# Altium schematic sheet NFC Controller.SchDoc("NFC Controller")
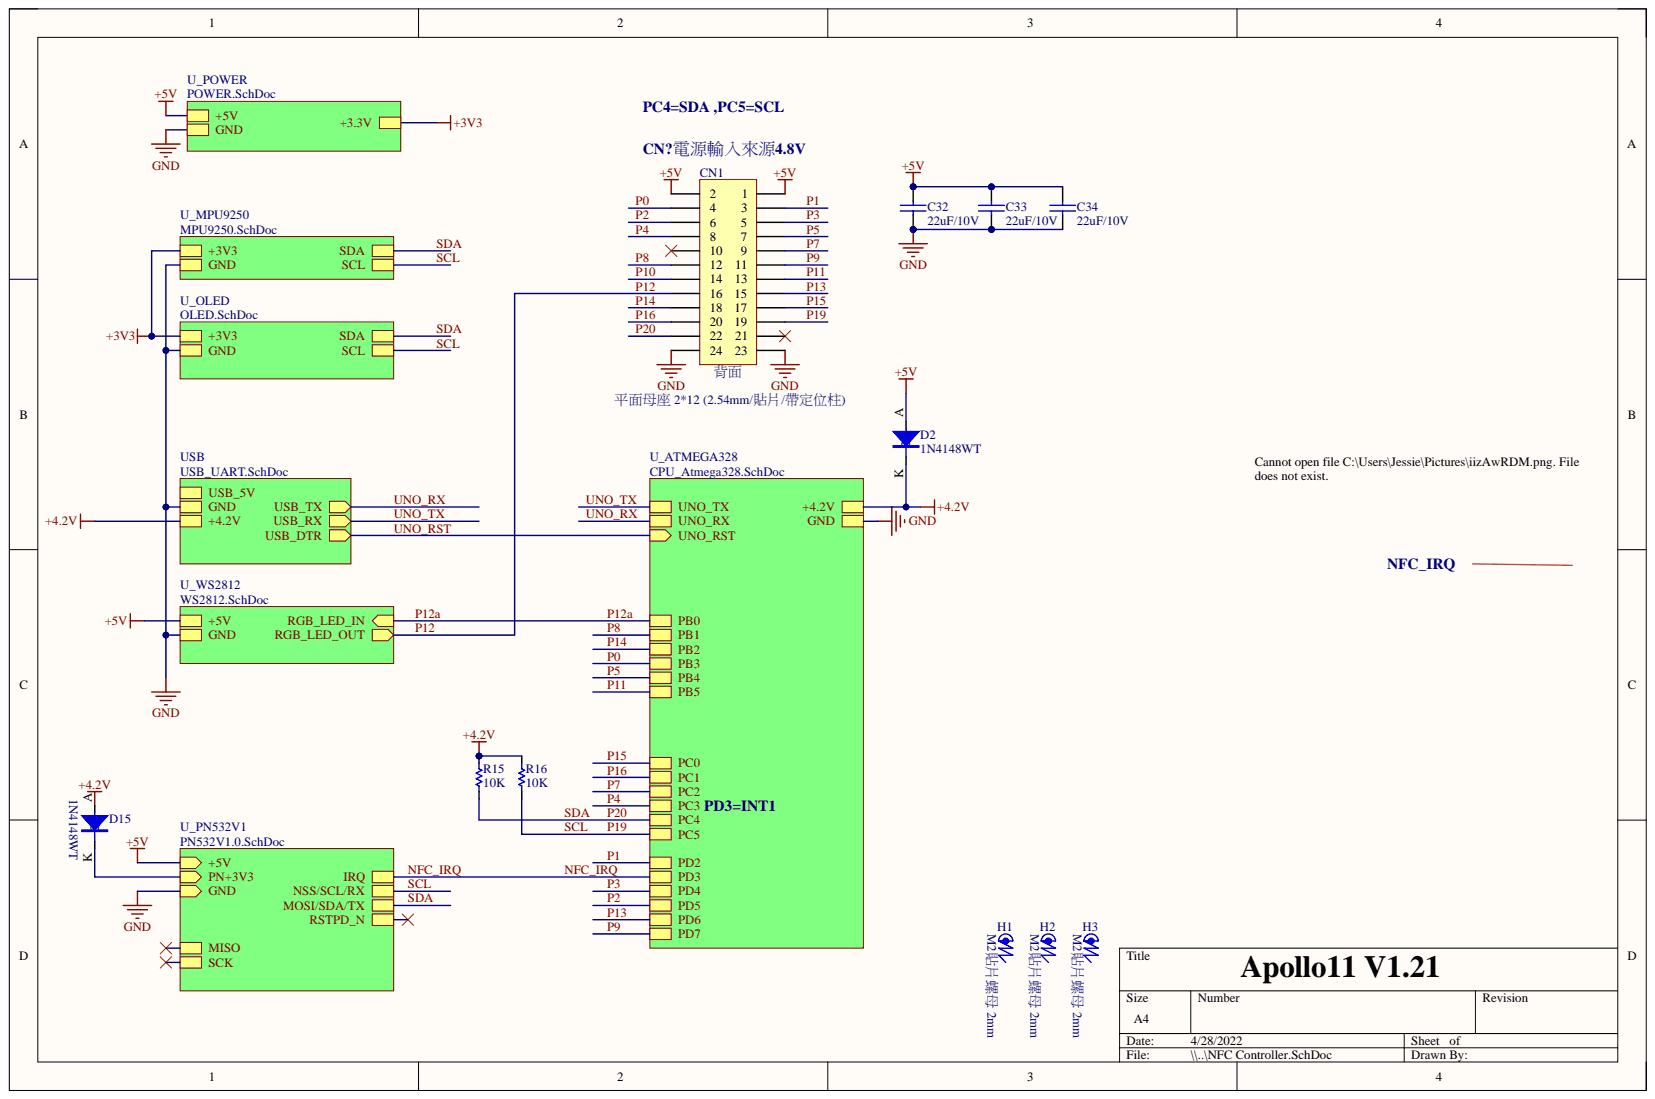
[diagram p0: NFC Controller.SchDoc]

## Parts
C32  22uF/10V  [0603C]
C33  22uF/10V  [0603C]
C34  22uF/10V  [0603C]
CN1  平面母座 2*12 (2.54mm/貼片/帶定位柱)  [SMD_pin12x2_2.54_M]
D2  1N4148WT  [SOD-523]
D15  1N4148WT  [SOD-523]
H1  M2貼片螺母 2mm  [SCREW_SMT2mm]
H2  M2貼片螺母 2mm  [SCREW_SMT2mm]
H3  M2貼片螺母 2mm  [SCREW_SMT2mm]
R15  10K  [0603]
R16  10K  [0603]

## Nets
+4.2V: D2.K D15.A R15.2 R16.2
+5V: C32.1 C33.2 C34.2 CN1.1 CN1.2 D2.A
GND: C32.2 C33.1 C34.1 CN1.23 CN1.24
P0: CN1.4
P1: CN1.3
P2: CN1.6
P3: CN1.5
P4: CN1.8
P5: CN1.7
P7: CN1.9
P8: CN1.12
P9: CN1.11
P10: CN1.14
P11: CN1.13
P12: CN1.16
P13: CN1.15
P14: CN1.18
P15: CN1.17
P16: CN1.20
P19: CN1.19 R16.1
P20: CN1.22 R15.1
NetCN1_10: CN1.10
NetCN1_21: CN1.21
NetD15_K: D15.K
+3V3:
MISO:
NFC_IRQ:
P12a:
RSTPD_N:
SCK:
UNO_RST:
UNO_RX:
UNO_TX:
USB_5V:

## Library data of the parts
C32-C34 = ProD8.tmp_15PF_44: 368010300788; Component Kind: Standard
CN1 = Header 12X2: 368020101703; Component Kind: Standard; LatestRevisionDate: 17-Jul-2002; LatestRevisionNote: Re-released for DXP Platform.; Library Name: Miscellaneous Connectors.IntLib; Publisher: Altium Limited
D2 D15 = DIODE-S: 368010700310; Component Kind: Standard; Library Name: DE2TV.Lib
H1-H3 = Screw_holes: 368011300353; Component Kind: Standard; Library Name: Protel_INIKI.SchLib
R15 R16 = Res1S: 3680102003368; Component Kind: Standard; Library Name: DE2TV.Lib
